# Altium schematic sheet MCU.SchDoc
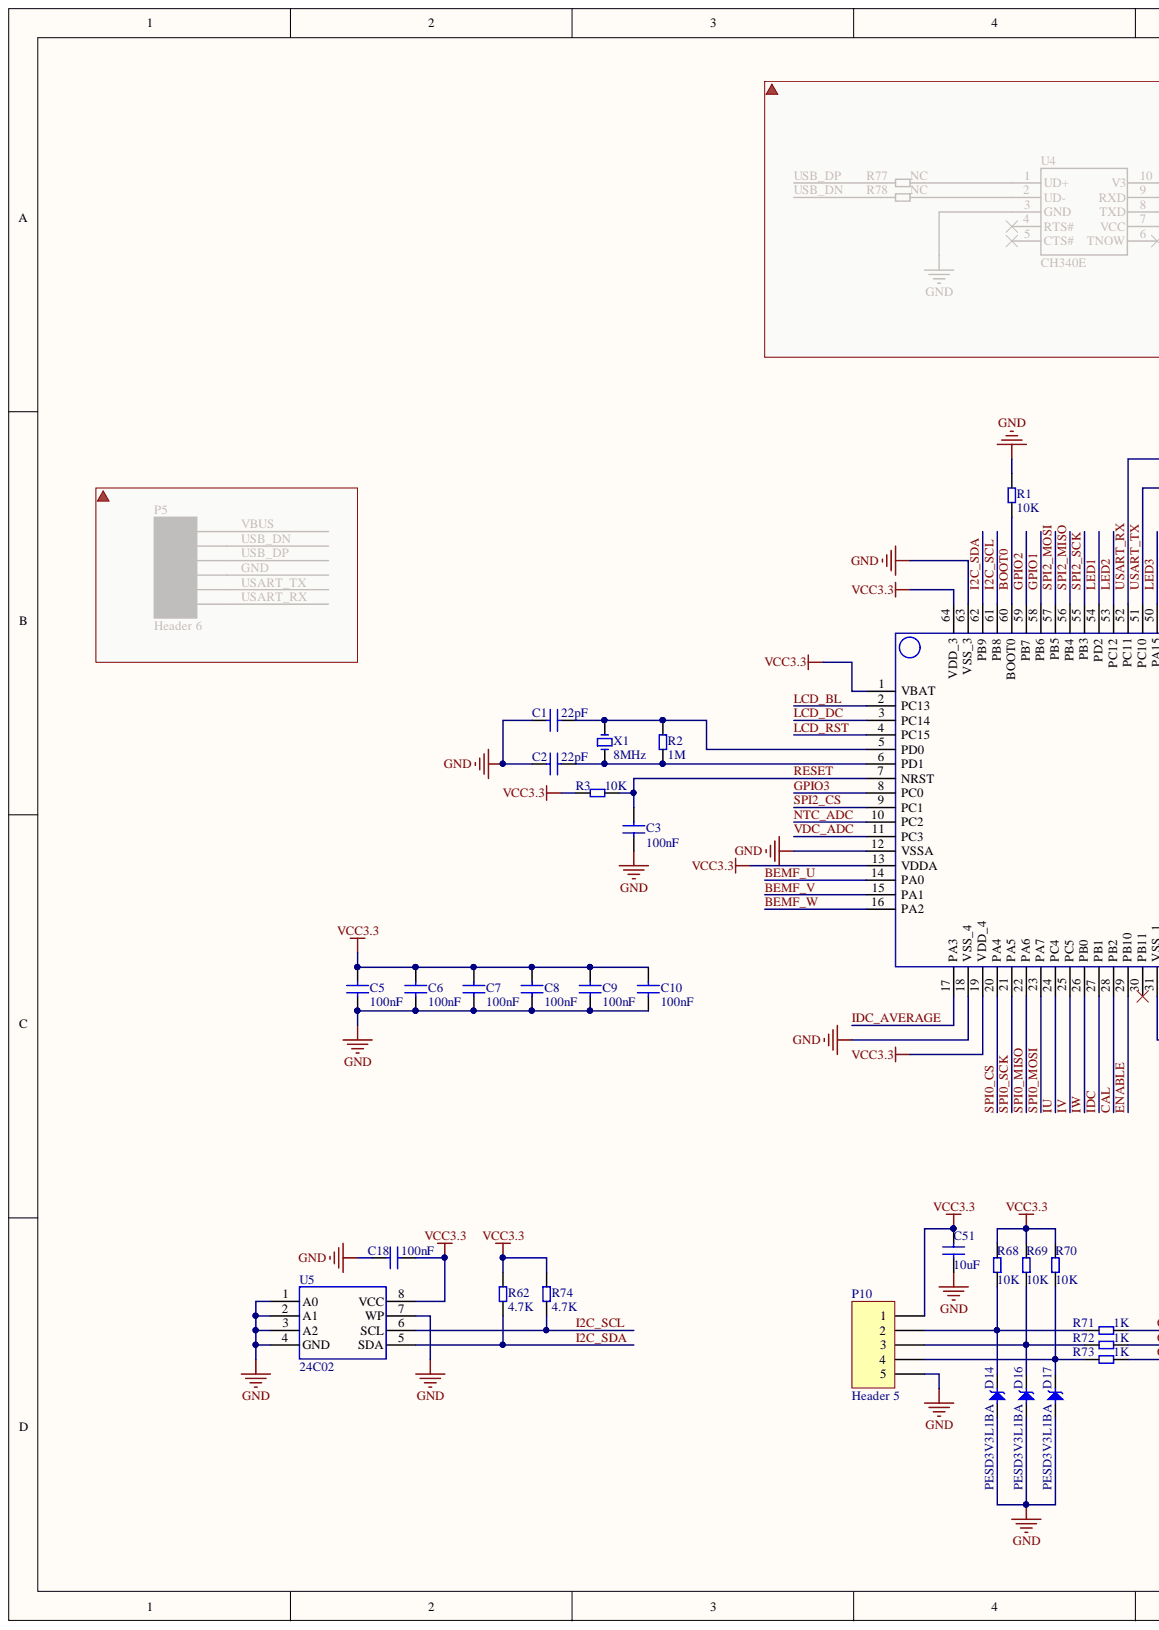
[diagram p0: MCU.SchDoc, left]
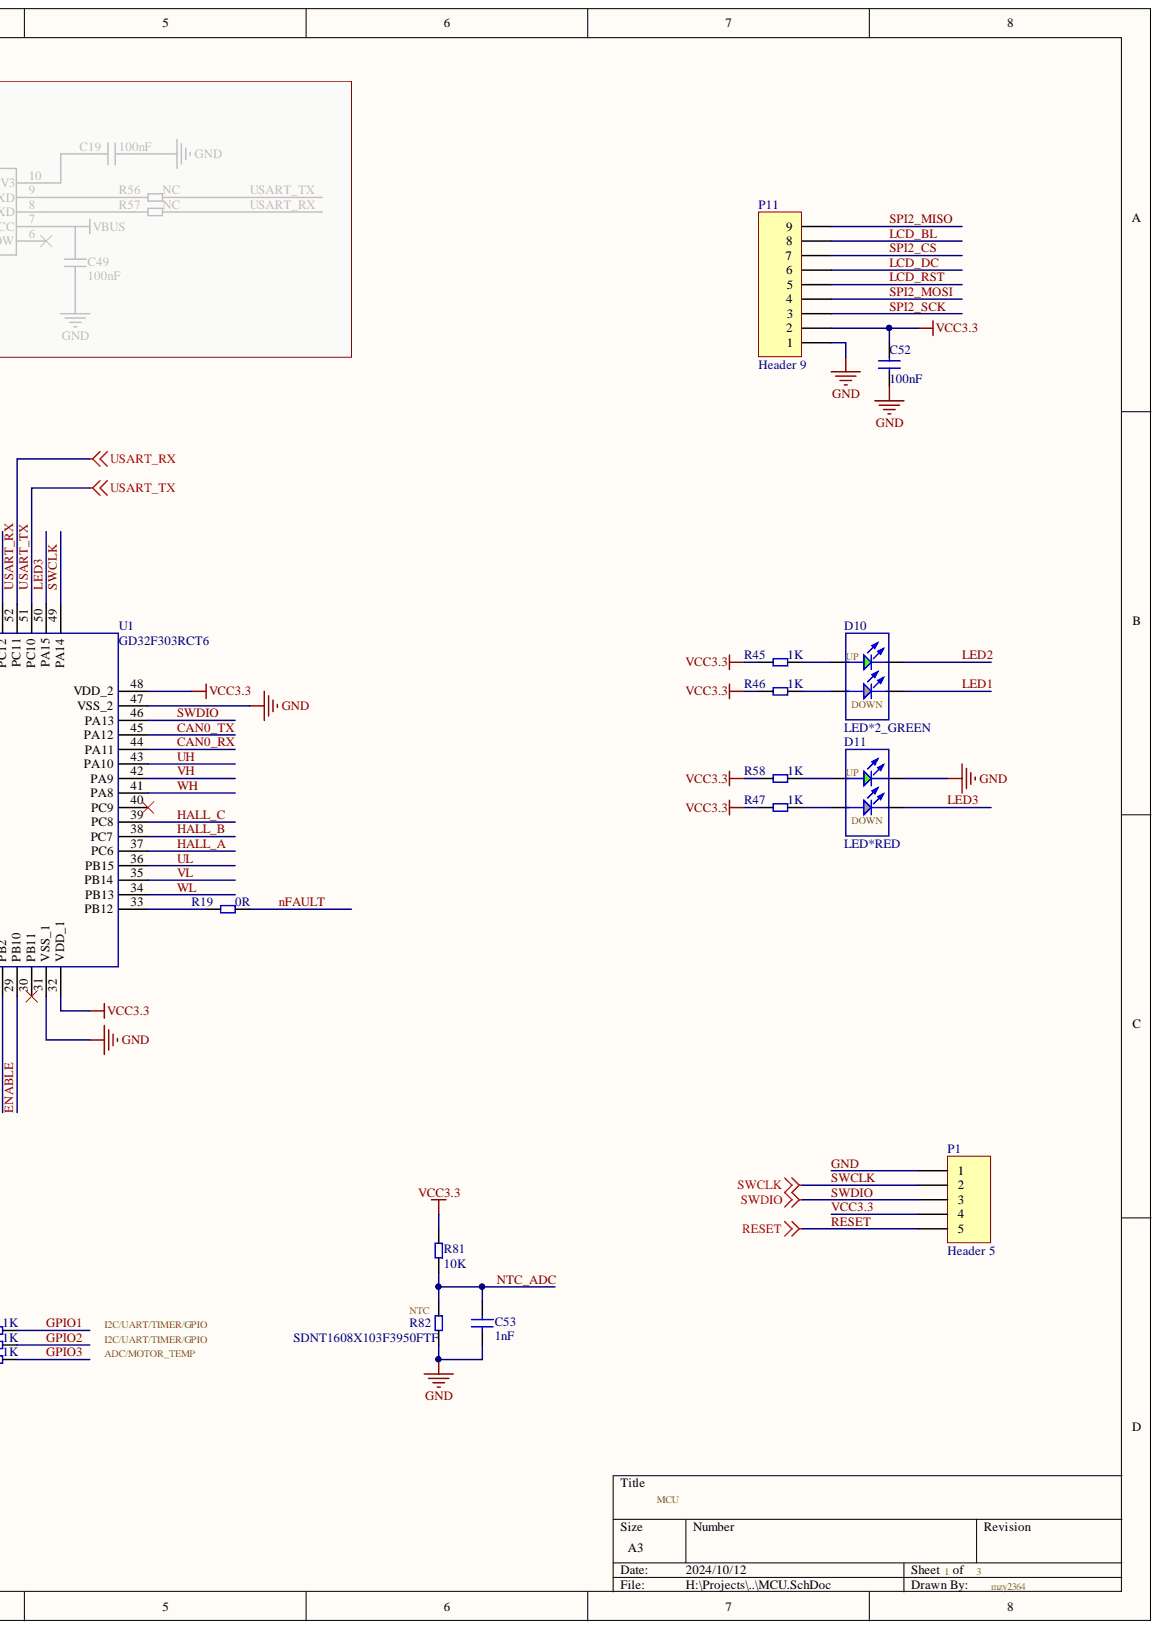
[diagram p1: MCU.SchDoc, right]

## Parts
C1  22pF  [C0603]
C2  22pF  [C0603]
C3  100nF  [C0603]
C5  100nF  [C0603]
C6  100nF  [C0603]
C7  100nF  [C0603]
C8  100nF  [C0603]
C9  100nF  [C0603]
C10  100nF  [C0603]
C18  100nF  [C0603]
C51  10uF  [C0603]
C52  100nF  [C0603]
C53  1nF  [C0603]
D10  LED*2_GREEN  [LED*2立式]
D11  LED*RED  [LED*2立式]
D14  PESD3V3L1BA  [SOD323]
D16  PESD3V3L1BA  [SOD323]
D17  PESD3V3L1BA  [SOD323]
P1  Header 5  [HDR2.54-LI-5P]
P10  Header 5  [KF2EDG3.81-WI-5P_1]
P11  Header 9  [HDR2.54-M-LI-9P]
R1  10K  [RES0603]
R2  1M  [RES0603]
R3  10K  [RES0603]
R19  0R  [RES0603]
R45  1K  [RES0603]
R46  1K  [RES0603]
R47  1K  [RES0603]
R58  1K  [RES0603]
R62  4.7K  [RES0603]
R68  10K  [RES0603]
R69  10K  [RES0603]
R70  10K  [RES0603]
R71  1K  [RES0603]
R72  1K  [RES0603]
R73  1K  [RES0603]
R74  4.7K  [RES0603]
R81  10K  [RES0603]
R82  SDNT1608X103F3950FTF  [RES0603]
U1  GD32F303RCT6  [LQFP64-0.5]
U5  24C02  [SOP8]
X1  8MHz  [OSC 5032-2P]

## Nets
BEMF_U: U1.14(PA0)
BEMF_V: U1.15(PA1)
BEMF_W: U1.16(PA2)
BOOT0: R1.1 U1.60(BOOT0)
CAL: U1.28(PB2)
CAN0_RX: U1.44(PA11)
CAN0_TX: U1.45(PA12)
ENABLE: U1.29(PB10)
GND: C1.1 C2.1 C3.1 C5.1 C6.1 C7.1 C8.1 C9.1 C10.1 C18.2 C51.1 C52.1 C53.1 D11.2-2 D14.1 D16.1 D17.1 P1.1 P10.5 P11.1 R1.2 R82.1 U1.12(VSSA) U1.18(VSS_4) U1.31(VSS_1) U1.47(VSS_2) U1.63(VSS_3) U5.1(A0) U5.2(A1) U5.3(A2) U5.4(GND) U5.7(WP)
GPIO1: R71.1 U1.58(PB6)
GPIO2: R72.1 U1.59(PB7)
GPIO3: R73.1 U1.8(PC0)
HALL_A: U1.37(PC6)
HALL_B: U1.38(PC7)
HALL_C: U1.39(PC8)
I2C_SCL: R74.2 U1.61(PB8) U5.6(SCL)
I2C_SDA: R62.2 U1.62(PB9) U5.5(SDA)
IDC: U1.27(PB1)
IDC_AVERAGE: U1.17(PA3)
IU: U1.24(PC4)
IV: U1.25(PC5)
IW: U1.26(PB0)
LCD_BL: P11.8 U1.2(PC13)
LCD_DC: P11.6 U1.3(PC14)
LCD_RST: P11.5 U1.4(PC15)
LED1: D10.1-2 U1.54(PD2)
LED2: D10.2-2 U1.53(PC12)
LED3: D11.1-2 U1.50(PA15)
nFAULT: R19.1
NTC_ADC: C53.2 R81.1 R82.2 U1.10(PC2)
RESET: C3.2 P1.5 R3.1 U1.7(NRST)
SPI0_CS: U1.20(PA4)
SPI0_MISO: U1.22(PA6)
SPI0_MOSI: U1.23(PA7)
SPI0_SCK: U1.21(PA5)
SPI2_CS: P11.7 U1.9(PC1)
SPI2_MISO: P11.9 U1.56(PB4)
SPI2_MOSI: P11.4 U1.57(PB5)
SPI2_SCK: P11.3 U1.55(PB3)
SWCLK: P1.2 U1.49(PA14)
SWDIO: P1.3 U1.46(PA13)
UH: U1.43(PA10)
UL: U1.36(PB15)
USART_RX: U1.52(PC11)
USART_TX: U1.51(PC10)
VCC3.3: C5.2 C6.2 C7.2 C8.2 C9.2 C10.2 C18.1 C51.2 C52.2 P1.4 P10.1 P11.2 R3.2 R45.2 R46.2 R47.2 R58.2 R62.1 R68.2 R69.2 R70.2 R74.1 R81.2 U1.1(VBAT) U1.13(VDDA) U1.19(VDD_4) U1.32(VDD_1) U1.48(VDD_2) U1.64(VDD_3) U5.8(VCC)
VDC_ADC: U1.11(PC3)
VH: U1.42(PA9)
VL: U1.35(PB14)
WH: U1.41(PA8)
WL: U1.34(PB13)
NetC1_2: C1.2 R2.2 U1.5(PD0) X1.2
NetC2_2: C2.2 R2.1 U1.6(PD1) X1.1
NetD10_1-1: D10.1-1 R46.1
NetD10_2-1: D10.2-1 R45.1
NetD11_1-1: D11.1-1 R47.1
NetD11_2-1: D11.2-1 R58.1
NetD14_2: D14.2 P10.2 R68.1 R71.2
NetD16_2: D16.2 P10.3 R69.1 R72.2
NetD17_2: D17.2 P10.4 R70.1 R73.2
NetR19_2: R19.2 U1.33(PB12)

Not connected: U1 30(PB11) 40(PC9)

## Library data of the parts
C1-C3 C5-C10 C18 C51 C53 = CAP: Material: X7R; Precision: 10%; Voltage: 50V; Component Kind: Standard; Library Name: mzy2364.SchLib
C52 = CAP: Component Kind: Standard; Library Name: mzy2364.SchLib
D10 D11 = LED*2: Component Kind: Standard; Library Name: mzy2364.SchLib
D14 D16 D17 = D TVS: Component Kind: Standard; Library Name: mzy2364.SchLib
P1 P10 = Header 5: Header, 5-Pin; Component Kind: Standard; LatestRevisionDate: 17-Jul-2002; LatestRevisionNote: Re-released for DXP Platform.; Library Name: Miscellaneous Connectors.IntLib; Signal Integrity: Connector; Publisher: Altium Limited
P11 = Header 9: Header, 9-Pin; Component Kind: Standard; LatestRevisionDate: 17-Jul-2002; LatestRevisionNote: Re-released for DXP Platform.; Library Name: Miscellaneous Connectors.IntLib; Signal Integrity: Connector; Publisher: Altium Limited
R1-R3 R19 R45-R47 R58 R62 R68-R74 R81 R82 = RES: Component Kind: Standard; Library Name: mzy2364.SchLib
U1 = GD32F303RBT6: Component Kind: Standard; Library Name: mzy2364.SchLib
X1 = XTAL-2P: Component Kind: Standard; Library Name: mzy2364.SchLib
U5 = 24C02: Component Kind: Standard; Library Name: mzy2364.SchLib
